# Altium schematic sheet SmallyMouse2.schdoc(SmallyMouse2)
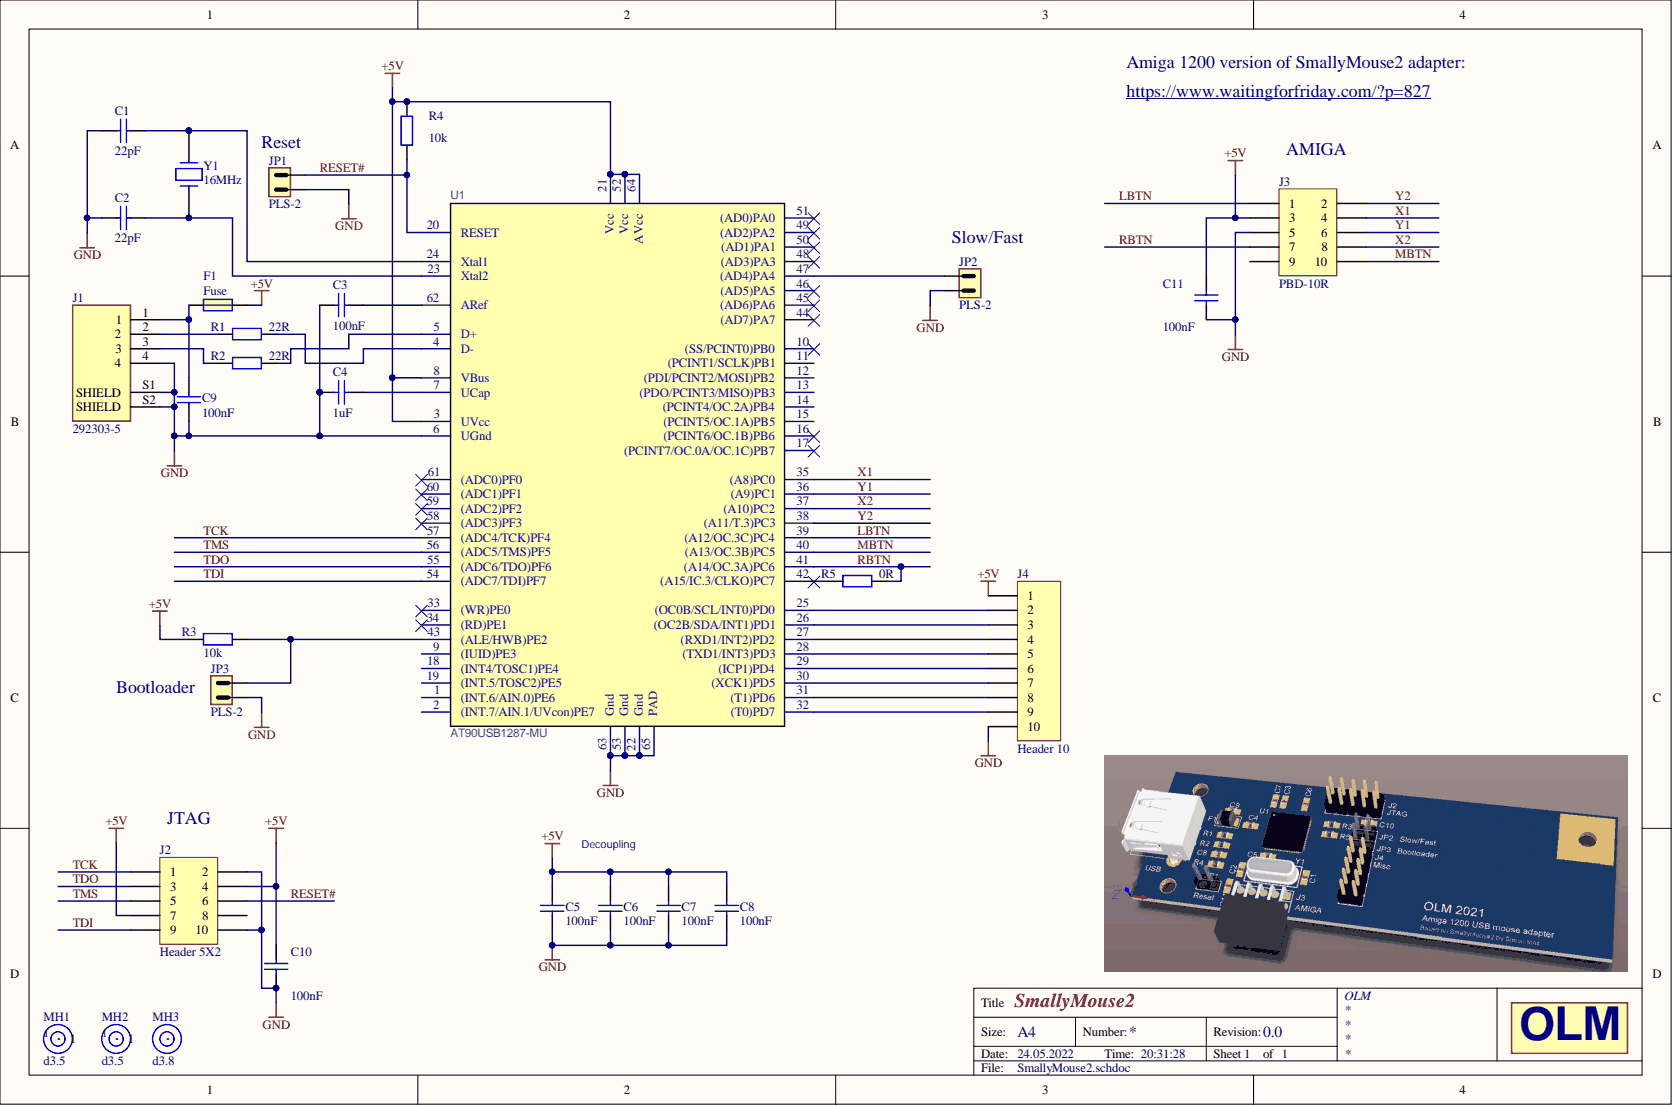
[diagram p0: SmallyMouse2.schdoc]

## Parts
C1  value 22pF  [SM/C_0805]  (hidden comment: Capacitor)
C2  value 22pF  [SM/C_0805]  (hidden comment: Capacitor)
C3  value 100nF  [SM/C_0805]  (hidden comment: Capacitor)
C4  value 1uF  [SM/C_0805]  (hidden comment: Capacitor)
C5  value 100nF  [SM/C_0805]  (hidden comment: Capacitor)
C6  value 100nF  [SM/C_0805]  (hidden comment: Capacitor)
C7  value 100nF  [SM/C_0805]  (hidden comment: Capacitor)
C8  value 100nF  [SM/C_0805]  (hidden comment: Capacitor)
C9  value 100nF  [SM/C_0805]  (hidden comment: Capacitor)
C10  value 100nF  [SM/C_0805]  (hidden comment: Capacitor)
C11  value 100nF  [SM/C_0805]  (hidden comment: Capacitor)
F1  Fuse  [SM/L_1210]
J1  292303-5  [TE_ 292303-5]
J2  Header 5X2  [PLD-10]
J3  PBD-10R  [PBD-10R]
J4  Header 10  [PLD-10]
JP1  value PLS-2  [pls-2]  (hidden comment: Разъем)
JP2  value PLS-2  [pls-2]  (hidden comment: Разъем)
JP3  value PLS-2  [pls-2]  (hidden comment: Разъем)
MH1  d3.5  [MTHOLE_3.5MM]  (no BOM)
MH2  d3.5  [MTHOLE_3.5MM]  (no BOM)
MH3  d3.8  [MTHOLE_3.8/0]  (no BOM)
R1  value 22R  [SM/R_0805]  (hidden comment: Resistor)
R2  value 22R  [SM/R_0805]  (hidden comment: Resistor)
R3  value 10k  [SM/R_0805]  (hidden comment: Resistor)
R4  value 10k  [SM/R_0805]  (hidden comment: Resistor)
R5  value 0R  [SM/R_0805]  (hidden comment: Resistor)
U1  AT90USB1287-MU  [QFN-64]
Y1  value 16MHz  [CRYSTAL_HC-49S]  (hidden comment: CRYSTAL)

## Nets
+5V: C5.1 C6.1 C7.1 C8.1 C10.2 C11.2 F1.2 J2.4 J2.7 J3.3 J4.1 R3.2 R4.2 U1.3(UVcc) U1.8(VBus) U1.21(Vcc) U1.52(Vcc) U1.64(AVcc)
GND: C1.2 C2.2 C3.2 C4.2 C5.2 C6.2 C7.2 C8.2 C9.2 C10.1 C11.1 J1.4 J1.S1(SHIELD) J1.S2(SHIELD) J2.2 J2.10 J3.5 J4.10 JP1.2 JP2.2 JP3.2 U1.6(UGnd) U1.22(Gnd) U1.53(Gnd) U1.63(Gnd) U1.65(PAD)
LBTN: J3.1 U1.39((A12/OC.3C)PC4)
MBTN: J3.10 U1.40((A13/OC.3B)PC5)
RBTN: J3.7 R5.2 U1.41((A14/OC.3A)PC6)
RESET#: J2.6 JP1.1 R4.1 U1.20(RESET)
TCK: J2.1 U1.57((ADC4/TCK)PF4)
TDI: J2.9 U1.54((ADC7/TDI)PF7)
TDO: J2.3 U1.55((ADC6/TDO)PF6)
TMS: J2.5 U1.56((ADC5/TMS)PF5)
X1: J3.4 U1.35((A8)PC0)
X2: J3.8 U1.37((A10)PC2)
Y1: J3.6 U1.36((A9)PC1)
Y2: J3.2 U1.38((A11/T.3)PC3)
NetC1_1: C1.1 U1.24(Xtal1) Y1.1
NetC2_1: C2.1 U1.23(Xtal2) Y1.2
NetC3_1: C3.1 U1.62(ARef)
NetC4_1: C4.1 U1.7(UCap)
NetC9_1: C9.1 F1.1 J1.1
NetJ1_2: J1.2 R1.1
NetJ1_3: J1.3 R2.1
NetJ4_2: J4.2 U1.25((OC0B/SCL/INT0)PD0)
NetJ4_3: J4.3 U1.26((OC2B/SDA/INT1)PD1)
NetJ4_4: J4.4 U1.27((RXD1/INT2)PD2)
NetJ4_5: J4.5 U1.28((TXD1/INT3)PD3)
NetJ4_6: J4.6 U1.29((ICP1)PD4)
NetJ4_7: J4.7 U1.30((XCK1)PD5)
NetJ4_8: J4.8 U1.31((T1)PD6)
NetJ4_9: J4.9 U1.32((T0)PD7)
NetJP2_1: JP2.1 U1.47((AD4)PA4)
NetJP3_1: JP3.1 R3.1 U1.43((ALE/HWB)PE2)
NetR1_2: R1.2 U1.4(D-)
NetR2_2: R2.2 U1.5(D+)
NetR5_1: R5.1 U1.42((A15/IC.3/CLKO)PC7)

Not connected: J2 8; J3 9; MH1 1; MH2 1; MH3 1; U1 1((INT.6/AIN.0)PE6) 2((INT.7/AIN.1/UVcon)PE7) 9((IUID)PE3) 10((SS/PCINT0)PB0) 11((PCINT1/SCLK)PB1) 12((PDI/PCINT2/MOSI)PB2) 13((PDO/PCINT3/MISO)PB3) 14((PCINT4/OC.2A)PB4) 15((PCINT5/OC.1A)PB5) 16((PCINT6/OC.1B)PB6) 17((PCINT7/OC.0A/OC.1C)PB7) 18((INT4/TOSC1)PE4) 19((INT.5/TOSC2)PE5) 33((WR)PE0) 34((RD)PE1) 44((AD7)PA7) 45((AD6)PA6) 46((AD5)PA5) 48((AD3)PA3) 49((AD2)PA2) 50((AD1)PA1) 51((AD0)PA0) 58((ADC3)PF3) 59((ADC2)PF2) 60((ADC1)PF1) 61((ADC0)PF0)

## Library data of the parts
C1-C9 = Cap ceramic: Ceramic capacitor SMD; Component Kind: Standard; Library Name: New.SCHLIB
C10 C11 = Cap ceramic: Ceramic capacitor SMD; Manufacturer: Samsung; Part: CL10B104KB8NNNL; Component Kind: Standard; Library Name: New.SCHLIB
F1 = Fuse_SMD: Component Kind: Standard; Library Name: New_home.SCHLIB
J1 = 292303-7: Conn USB 2.0 Type A RCP 4 POS Solder RA Thru-Hole 4 Terminal 1 Port Box/Tray; MF: TE Connectivity; Availability: Unavailable; Component Kind: Standard; Library Name: 292303-7.IntLib; MP: 292303-5; Package: None; Part: 292303-5; Price: None; SnapEDA_Link: https://www.snapeda.com/parts/292303-7/TE%20Connectivity%20AMP%20Connectors/view-part/814934/?ref=snap; Value: 292303-5
J2 J3 = Header 5X2: Header, 5-Pin, Dual row; Component Kind: Standard; Library Name: Miscellaneous Connectors.IntLib; Signal Integrity: Connector
  J2: LatestRevisionDate: 17-Jul-2002; LatestRevisionNote: Re-released for DXP Platform.; Publisher: Altium Limited
  J3: Value: PBD-10R
J4 = Header 10: Header, 10-Pin; Component Kind: Standard; LatestRevisionDate: 17-Jul-2002; LatestRevisionNote: Re-released for DXP Platform.; Library Name: Miscellaneous Connectors.IntLib; Signal Integrity: Connector; Publisher: Altium Limited
JP1-JP3 = Jumper2: Jumper Wire; Manufacturer: Generic Components; Component Kind: Standard; Library Name: New_home.SCHLIB; Published: 1999
MH1 MH2 = MTHOLE_3.5: Library Name: MECH_Library_home.SchLib
MH3 = MTHOLE_3.8: Library Name: MECH_Library_home.SchLib
R1-R5 = Res2: Part: 22R 5% 0603; Component Kind: Standard; Description: Resistor; LatestRevisionDate: 17-Jul-2002; LatestRevisionNote: Re-released for DXP Platform.; Library Name: Miscellaneous Devices.IntLib; PackageReference: AXIAL-0.4; Published: 8-Jun-2000; Signal Integrity: Res; Simulation: RESISTOR; Publisher: Altium Limited
Y1 = CRYSTAL: Part: HC49/U-S 16MHz; Component Kind: Standard; Description: Crystal; Library Name: New.SCHLIB
U1 = AT90USB1287-MU: Component Kind: Standard; Library Name: Microchip Microcontroller 8-Bit AVR.SchLib
